# Altium schematic sheet Resistive 2.SchDoc
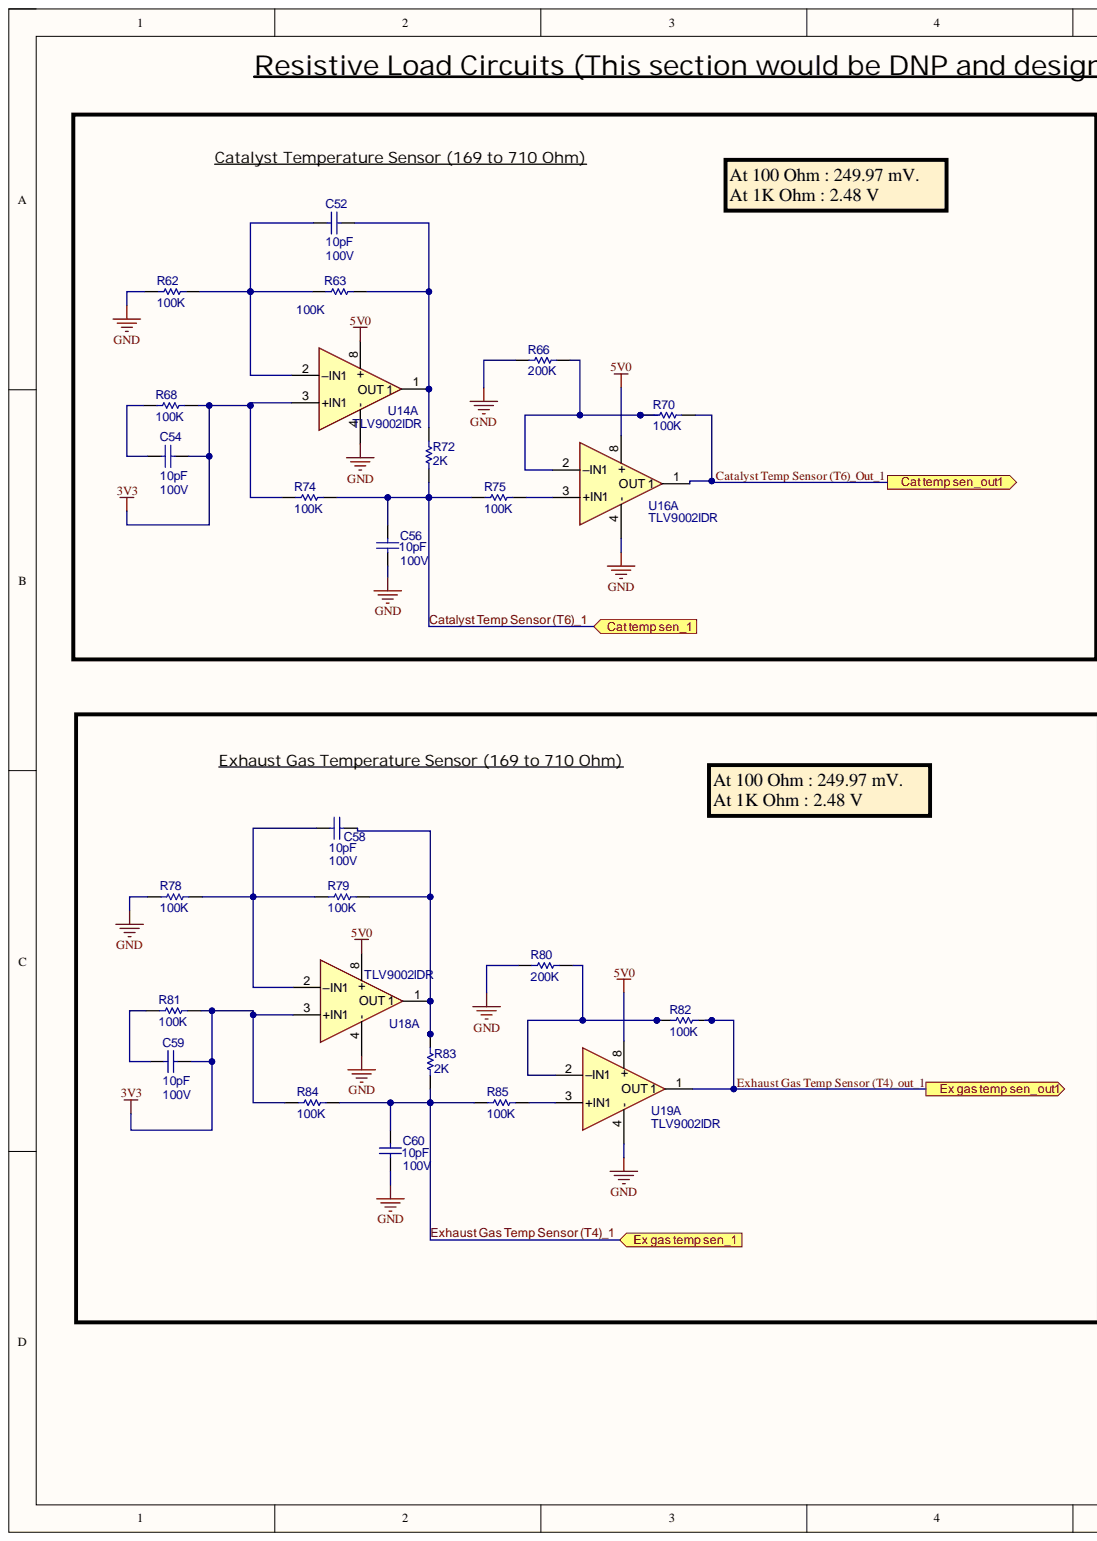
[diagram p0: Resistive 2.SchDoc, left]
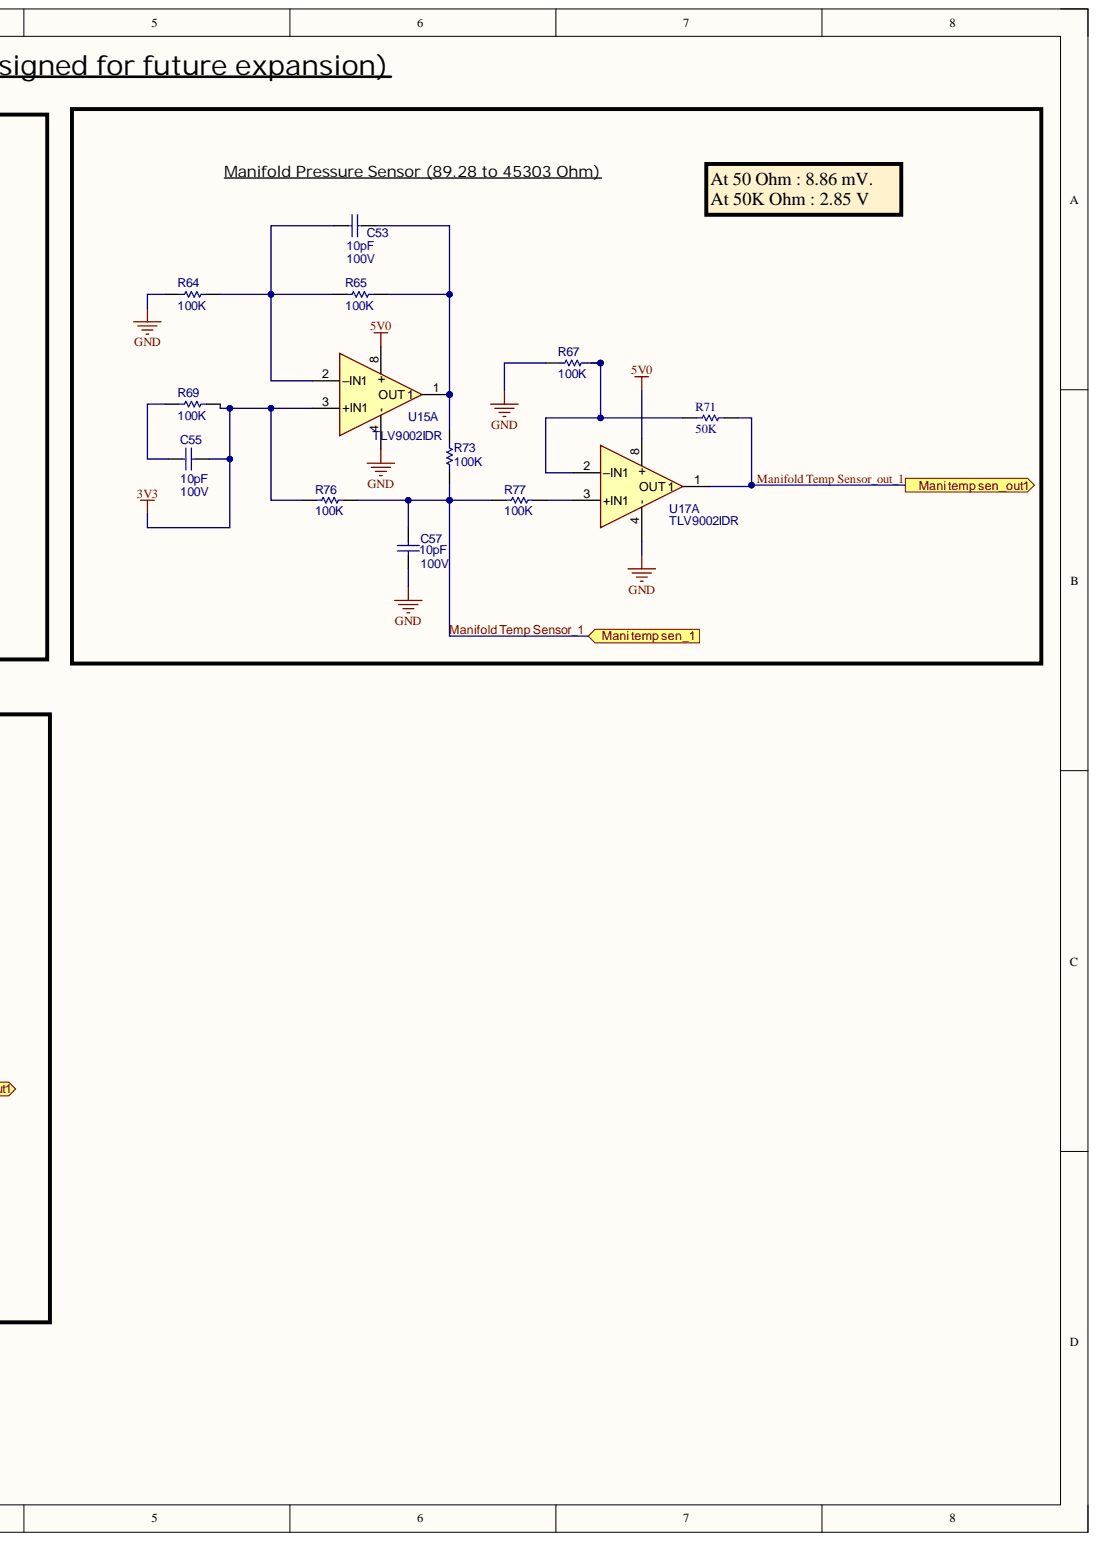
[diagram p1: Resistive 2.SchDoc, right]

## Parts
C52  10pF  Voltage: 100V  [CAP-0603]
C53  10pF  Voltage: 100V  [CAP-0603]
C54  10pF  Voltage: 100V  [CAP-0603]
C55  10pF  Voltage: 100V  [CAP-0603]
C56  10pF  Voltage: 100V  [CAP-0603]
C57  10pF  Voltage: 100V  [CAP-0603]
C58  10pF  Voltage: 100V  [CAP-0603]
C59  10pF  Voltage: 100V  [CAP-0603]
C60  10pF  Voltage: 100V  [CAP-0603]
R62  100K  [RES-0603]
R63  100K  [RES-0603]
R64  100K  [RES-0603]
R65  100K  [RES-0603]
R66  200K  [RES-0603]
R67  100K  [RES-0603]
R68  100K  [RES-0603]
R69  100K  [RES-0603]
R70  100K  [RES-0603]
R71  50K  [RES_0805]
R72  2K  [RES-0603]
R73  100K  [RES-0603]
R74  100K  [RES-0603]
R75  100K  [RES-0603]
R76  100K  [RES-0603]
R77  100K  [RES-0603]
R78  100K  [RES-0603]
R79  100K  [RES-0603]
R80  200K  [RES-0603]
R81  100K  [RES-0603]
R82  100K  [RES-0603]
R83  2K  [RES-0603]
R84  100K  [RES-0603]
R85  100K  [RES-0603]
U14  TLV9002IDR  [SOIC127P599X175-8N]  (part A)
U15  TLV9002IDR  [SOIC127P599X175-8N]  (part A)
U16  TLV9002IDR  [SOIC127P599X175-8N]  (part A)
U17  TLV9002IDR  [SOIC127P599X175-8N]  (part A)
U18  TLV9002IDR  [SOIC127P599X175-8N]  (part A)
U19  TLV9002IDR  [SOIC127P599X175-8N]  (part A)

## Ports
Cat temp sen_1, Cat temp sen_out1, Ex gas temp sen_1, Ex gas temp sen_out1, Mani temp sen_1, Mani temp sen_out1

## Nets
3V3: C54.1 C55.1 C59.1 R68.1 R69.1 R74.2 R76.2 R81.1 R84.2 U14.3(+IN1) U15.3(+IN1) U18.3(+IN1)
5V0: U14.8(+) U15.8(+) U16.8(+) U17.8(+) U18.8(+) U19.8(+)
GND: C56.2 C57.2 C60.2 R62.2 R64.2 R66.2 R67.2 R78.2 R80.2 U14.4(-) U15.4(-) U16.4(-) U17.4(-) U18.4(-) U19.4(-)
Catalyst Temp Sensor (T6)_1: C56.1 R72.1 R74.1 R75.2 ; port Cat temp sen_1
Catalyst Temp Sensor (T6)_Out_1: R70.1 U16.1(OUT_1) ; port Cat temp sen_out1
Exhaust Gas Temp Sensor (T4)_1: C60.1 R83.1 R84.1 R85.2 ; port Ex gas temp sen_1
Exhaust Gas Temp Sensor (T4)_out_1: R82.1 U19.1(OUT_1) ; port Ex gas temp sen_out1
Manifold Temp Sensor_1: C57.1 R73.2 R76.1 R77.2 ; port Mani temp sen_1
Manifold Temp Sensor_out_1: R71.2 U17.1(OUT_1) ; port Mani temp sen_out1
NetC52_1: C52.1 R63.1 R72.2 U14.1(OUT_1)
NetC52_2: C52.2 R62.1 R63.2 U14.2(–IN1)
NetC53_1: C53.1 R65.1 R73.1 U15.1(OUT_1)
NetC53_2: C53.2 R64.1 R65.2 U15.2(–IN1)
NetC54_2: C54.2 R68.2
NetC55_2: C55.2 R69.2
NetC58_1: C58.1 R79.1 R83.2 U18.1(OUT_1)
NetC58_2: C58.2 R78.1 R79.2 U18.2(–IN1)
NetC59_2: C59.2 R81.2
NetR66_1: R66.1 R70.2 U16.2(–IN1)
NetR67_1: R67.1 R71.1 U17.2(–IN1)
NetR75_1: R75.1 U16.3(+IN1)
NetR77_1: R77.1 U17.3(+IN1)
NetR80_1: R80.1 R82.2 U19.2(–IN1)
NetR85_1: R85.1 U19.3(+IN1)

## Library data of the parts
C52 C53 C55-C60 = CAP: CAP CER 10PF 100V C0G/NP0 0603,C0603X100J1GACAUTO; Manufacturer: Murata Electronics; Part Number: GCM1885C2A100JA16D; Tolerance: ±1%; Component Kind: Standard; Library Name: Daughter_board_SE3_V1.SCHLIB; Package: 0603; Technology: SMT; Value: 10pF
C54 = CAP: CAP CER 10PF 100V C0G/NP0 0603, C0603X100J1GACAUTO; Manufacturer: Murata Electronics; Part Number: GCM1885C2A100JA16D; Tolerance: ±1%; Component Kind: Standard; Library Name: Daughter_board_SE3_V1.SCHLIB; Package: 0603; Technology: SMT; Value: 10pF
R62-R65 R67-R70 R73-R79 R81 R82 R84 R85 = RES-2: RES SMD 100K OHM 1% 1/10W 0603,MCT06030C1003FP500; Manufacturer: YAGEO; Part Number: AC0603FR-07100KL; Power Rating: 100mW; Component Kind: Standard; Library Name: Daughter_board_SE3_V1.SCHLIB; Package: 0603; Tolerance: ±1%; Value: 100K
R66 R80 = RES-2: RES SMD 200K OHM 1% 1/10W 0603,  CHV0603AFX-2003ELF; Manufacturer: YAGEO; Part Number: AC0603FR-07200KL; Power Rating: 100mW; Component Kind: Standard; Library Name: Daughter_board_SE3_V1.SCHLIB; Package: 0603; Tolerance: ±1%; Value: 200K
R71 = Res_50k_0.5W_0.1%_0805: Res_50k_0.5W_0.1%_0805, MFP50SBBE52-50K; Component Kind: Standard; Library Name: GENSET CONTROLLER BETA V01.SCHLIB
R72 R83 = RES-2_32: RES SMD 2K OHM 1% 1/10W 0603,RCA06032K00FKEA; Manufacturer: YAGEO; Part Number: AC0603FR-072KL; Power Rating: 100mW; Component Kind: Standard; Package: 0603; Tolerance: ±1%; Value: 2K; Library Name: GENSET CONTROLLER BETA V01.SCHLIB
U14-U19 = TLV9002IDR: IC OPAMP GP 2 CIRCUIT 8SOIC; Manufacturer: Texas Instruments; Component Kind: Standard; Part Number: TLV9002IDR; Library Name: GENSET CONTROLLER BETA V1.SCHLIB
